# Altium schematic sheet 24VDC_IN.SchDoc
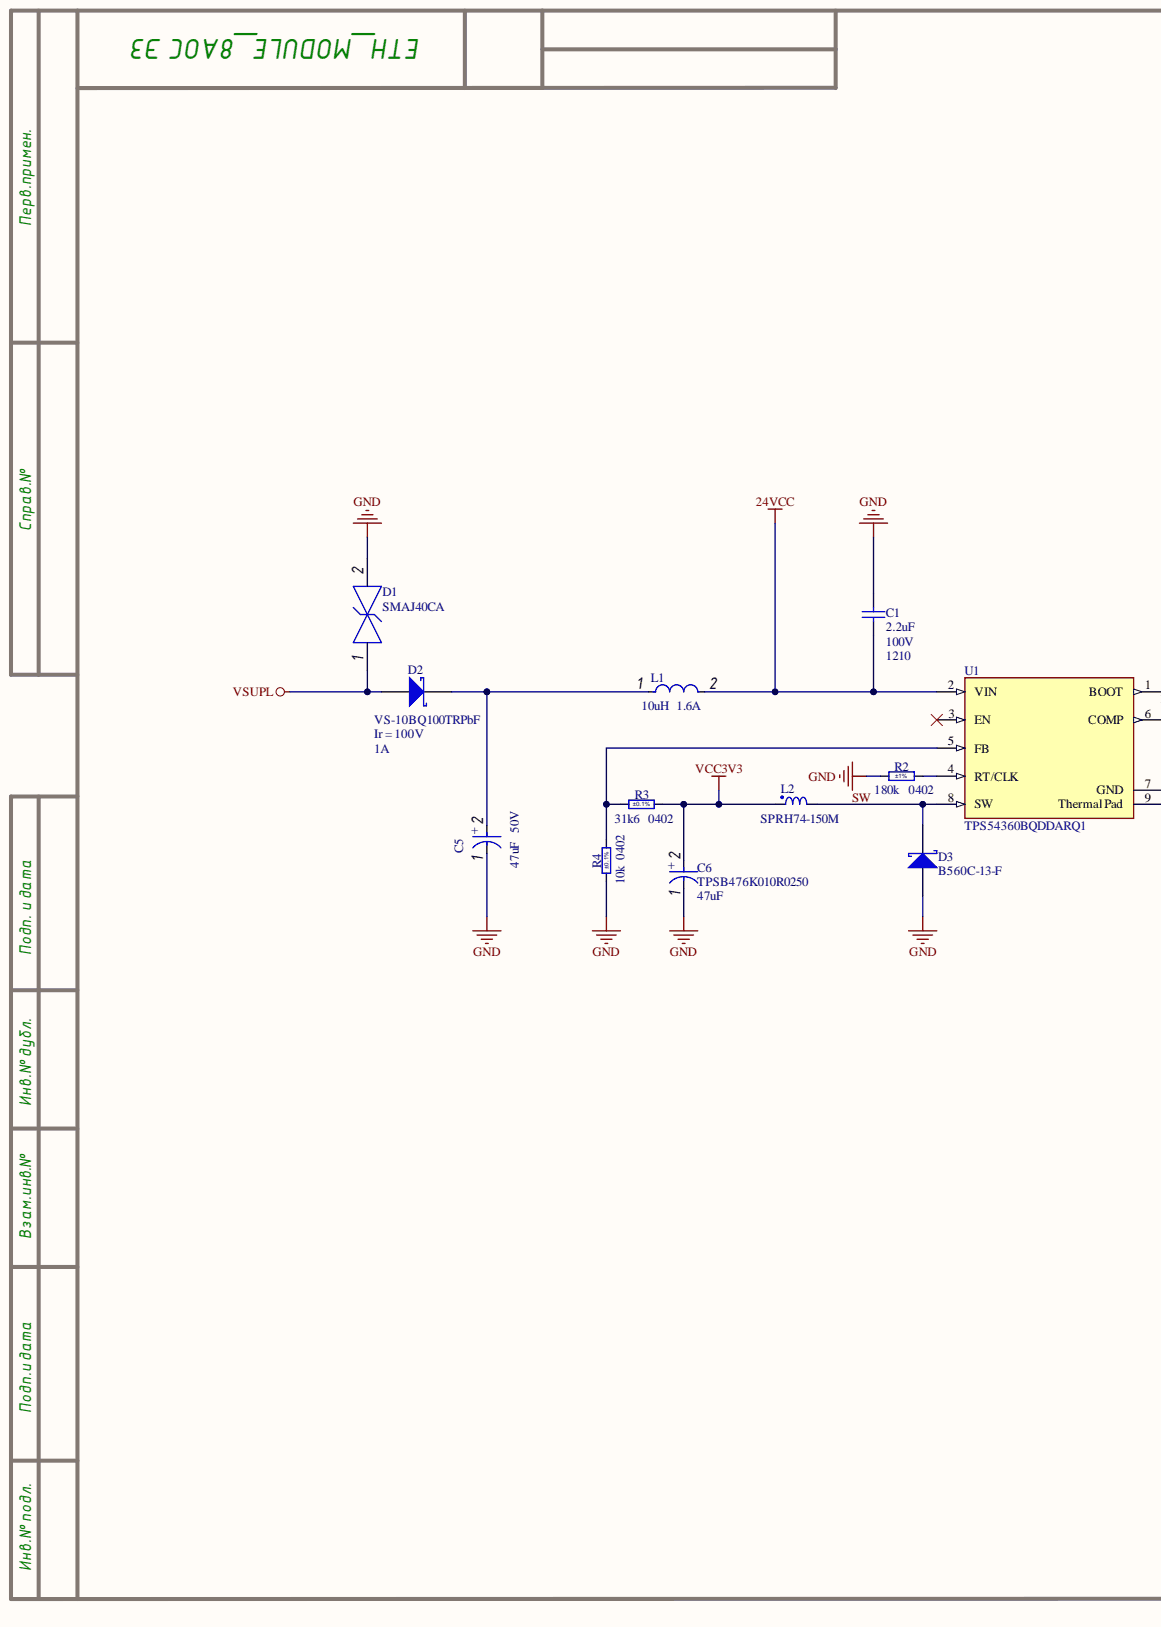
[diagram p0: 24VDC_IN.SchDoc, left]
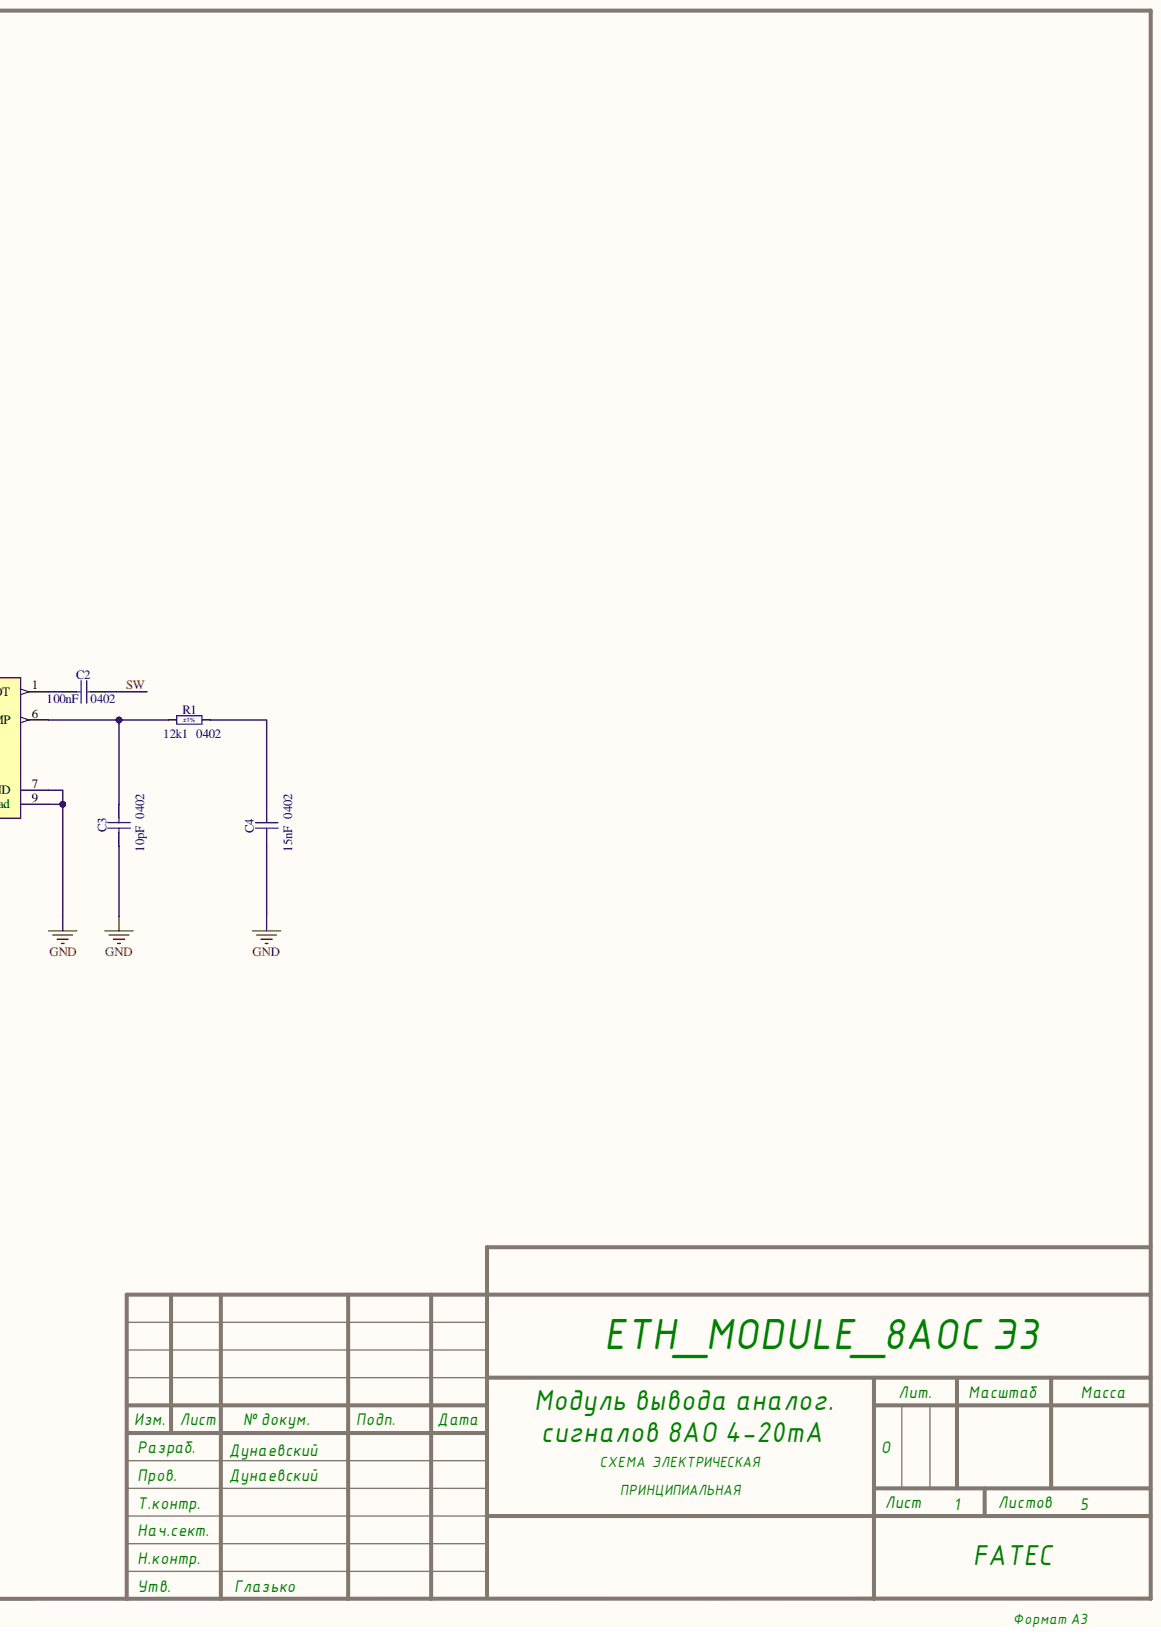
[diagram p1: 24VDC_IN.SchDoc, right]

## Parts
C1  2.2uF  Case: 1210  Voltage: 100V  [CAPC3225X230N]
C2  100nF  Case: 0402  [CAPC1005X55N]
C3  10pF  Case: 0402  [CAPC1005X55N]
C4  15nF  Case: 0402  [CAPC1005X55N]
C5  Capacitance: 47uF  Voltage: 50V  [FP-FKE-MFG]  (hidden comment: EEEFK1H470P)
C6  TPSB476K010R0250  Capacitance: 47uF  [FP-TPSB-MFG]
D1  SMAJ40CA  [FP-SMAJ-MFG]
D2  VS-10BQ100TRPbF  Voltage - DC Reverse (Vr) (Max): Ir = 100V  [VISH-SMB-2_V]
D3  B560C-13-F  [DIOD-B5X0C-2_M]
L1  Current Rating: 1.6A  Inductance: 10uH  [FP-LQH5BPB_T0-MFG]  (hidden comment: LQH5BPN100MT0L)
L2  SPRH74-150M  [B82472G6103M000]
R1  12k1  Case: 0402  Tolerance: ±1%  [RESC1005X40N]
R2  180k  Case: 0402  Tolerance: ±1%  [RESC1005X40N]
R3  31k6  Case: 0402  Tolerance: ±0.1%  [RESC1005X35N]
R4  10k  Case: 0402  Tolerance: ±0.1%  [RESC1005X35N]
U1  TPS54360BQDDARQ1  [FP-DDA0008E-MFG]

## Nets
24VCC: C1.1 L1.2 U1.2(VIN)
GND: C1.2 C3.1 C4.1 C5.1 C6.1 D1.2 D3.2 R2.1 R4.1 U1.7(GND) U1.9(Thermal_Pad)
VCC3V3: C6.2 L2.1 R3.2
VSUPL: D1.1 D2.1
SW: C2.2 D3.1 L2.2 U1.8(SW)
NetC2_1: C2.1 U1.1(BOOT)
NetC3_2: C3.2 R1.1 U1.6(COMP)
NetC4_2: C4.2 R1.2
NetC5_2: C5.2 D2.2 L1.1
NetR2_2: R2.2 U1.4(RT/CLK)
NetR3_1: R3.1 R4.2 U1.5(FB)

Not connected: U1 3(EN)

## Library data of the parts
C1 = CC1210_2.2UF_100V_10%_X7R_MURATA_GCJ32DR72A225KA01L: Non-polarised capacitor; Manufacturer: MURATA; Part Description: Soft Termination SMD Chip Monolithic Ceramic Capacitor for Automotive; Database Table Name: Capacitors SMD; TC: X7R; Tolerance: ±10%; Component Kind: Standard; Component Type: Standard; ComponentHeight: 2.3mm; CreateDate: 10.05.2017; HelpURL: \\cern.ch\dfs\Applications\Altium\Datasheets\CC1210_MURATA_GCJ32DR72A225KA01L.pdf; LatestRevisionDate: 10.05.2017; Library Name: Capacitors.DbLib; Manufacturer Part Number: GCJ32DR72A225KA01L; Mounted: Yes; Part Number: CC1210_2.2UF_100V_10%_X7R_MURATA_GCJ32DR72A225KA01L; Pin Count: 2; Sense: No; SMD: Yes; Socket: No; Status: Not Recommended; Value: 2.2uF; Author: CERN DEM JLC
C2 = CC0402_100NF_16V_10%_X7R: Non-polarised capacitor; Manufacturer: GENERIC; Manufacturer1 Part Number: GRM155R71C104KA88D; Part Description: SMD Multilayer Chip Ceramic Capacitor; Database Table Name: Capacitors SMD; TC: X7R; Tolerance: ±10%; Voltage: 16V; Component Kind: Standard; Component Type: Standard; CreateDate: 05.12.2013; HelpURL: \\cern.ch\dfs\Applications\Altium\Datasheets\CC0402_X7R_MURATA_GRM.pdf; LatestRevisionDate: 03.12.2007; Library Name: Capacitors.DbLib; Manufacturer1 ComponentHeight: 0.55mm; Manufacturer1 Example: MURATA; Manufacturer Part Number: CC0402_100NF_16V_10%_X7R; Mounted: Yes; Part Number: CC0402_100NF_16V_10%_X7R; Pin Count: 2; Sense: No; SMD: Yes; Socket: No; Status: Not Recommended; Value: 100nF; Author: CERN DEM JLC
C3 = CC0402_10PF_50V_5%_C0G: Non-polarised capacitor; Manufacturer: GENERIC; Manufacturer1 Part Number: C0402C100J5GACTU; Part Description: SMD Multilayer Chip Ceramic Capacitor; Database Table Name: Capacitors SMD; TC: C0G/NP0; Tolerance: ±5%; Voltage: 50V; Component Kind: Standard; Component Type: Standard; CreateDate: 03.12.2007; HelpURL: \\cern.ch\dfs\Applications\Altium\Datasheets\CC0402_C0G_KEMET_(Commercial Grade).pdf; LatestRevisionDate: 22.04.2015; Library Name: Capacitors.DbLib; Manufacturer1 ComponentHeight: 0.55mm; Manufacturer1 Example: KEMET; Manufacturer Part Number: CC0402_10PF_50V_5%_C0G; Mounted: Yes; Part Number: CC0402_10PF_50V_5%_C0G; Pin Count: 2; Sense: No; SMD: Yes; Socket: No; Status: Preferred; Value: 10pF; Author: CERN DEM JLC
C4 = CC0402_15NF_50V_5%_X7R: Non-polarised capacitor; Manufacturer: GENERIC; Manufacturer1 Part Number: C0402C153J5RACTU; Part Description: SMD Multilayer Chip Ceramic Capacitor; Database Table Name: Capacitors SMD; TC: X7R; Tolerance: ±5%; Voltage: 50V; Component Kind: Standard; Component Type: Standard; CreateDate: 02.09.2019; HelpURL: \\cern.ch\dfs\Applications\Altium\Datasheets\CC0402_X7R_KEMET.pdf; LatestRevisionDate: 02.09.2019; Library Name: Capacitors.DbLib; Manufacturer1 ComponentHeight: 0.55mm; Manufacturer1 Example: KEMET; Manufacturer Part Number: CC0402_15NF_50V_5%_X7R; Mounted: Yes; Part Number: CC0402_15NF_50V_5%_X7R; Pin Count: 2; Sense: No; SMD: Yes; Socket: No; Status: Preferred; Value: 15nF; Author: CERN DEM BC
C5 = CMP-05427-000074-1: CAP ALUM 47UF 20% 50V SMD; Dielectric Material: Aluminium; ESR (Equivalent Series Resistance): 680mR; Leakage Current: 3uA; Life (Hours): 2000Hour; Ripple Current: 195mA; Case/Package: Radial; Component Kind: Standard; Construction: Cylindrical; Diameter: 8mm; Height: 6.2mm; Height - Seated (Max): 0.244inch; Impedance: 680mR; Lead Free: Lead Free; Length: 6.2mm; Library Name: Altium Content Vault; Material: Aluminium; Max Operating Temperature: 105°C; Min Operating Temperature: -55°C; Mount: Surface Mount; Number of Pins: 2; Package Quantity: 1000; Packaging: Tape and Reel; Radiation Hardening: No; REACH SVHC: No SVHC; Ripple Current (AC): 195mA; RoHS Compliant: Yes; Series: FK; Size: 6.2mm; Termination: SMD/SMT; Tolerance: 20%; Voltage Rating: 50V; Voltage Rating (DC): 50V; Width: 8.3mm
C6 = CMP-04424-000465-1: CAP TANT 47UF 10% 10V 1411; Dielectric Material: Tantalum; Dissipation Factor: 8%; ESR (Equivalent Series Resistance): 250mR; Leakage Current: 4.7uA; Polarity: Polar; Case Code (Imperial): 1210; Case Code (Metric): 3528; Case/Package: 1210; Component Kind: Standard; Construction: Flat; Depth: 2.8mm; Features: General Purpose; Height: 1.9mm; Height - Seated (Max): 0.083inch; Lead Free: Lead Free; Lead Pitch: 1.4mm; Length: 3.5mm; Library Name: Altium Content Vault; Max Operating Temperature: 125°C; Min Operating Temperature: -55°C; Mount: Surface Mount; Package Quantity: 1; Packaging: Tape and Reel; Radiation Hardening: No; REACH SVHC: No SVHC; RoHS Compliant: Yes; Series: TPS; Termination: SMD/SMT; Tolerance: 10%; Voltage: 10V; Voltage Rating: 10V; Voltage Rating (DC): 10V; Width: 0.11inch
D1 = CMP-08607-000026-1: TVS DIODE 40V 64.5V DO214AC; Manufacturer: Littelfuse Inc; Breakdown Voltage: 44.4V; Direction: Bidirectional; Max Junction Temp: +150°C; Number Of Channels: 1; Peak Pulse Current: 6.2A; Peak Pulse Power: 400W; Automotive: No; Category: Diode; Clamping Voltage: 64.5V; Component Kind: Standard; ComponentLink2Description: Datasheet; ComponentLink2URL: https://www.littelfuse.com/~/media/electronics/datasheets/tvs_diodes/littelfuse_tvs_diode_smaj_datasheet.pdf.pdf; Device Class L1: Circuit Protection; Device Class L2: TVS Diodes; Device Class L3: unset; Digikey Description: TVS DIODE 40V 64.5V DO214AC; Height: 2.29mm; Lead Free: Yes; Library Name: Altium Content Vault; Manufacturer Part Number: SMAJ40CA; Mouser Description: Transient Voltage Suppressor, TVS, SMAJ Series, Bidirectional, 40 V, DO-214AC, 2, 44.4 V; Octopart: https://octopart.com/smaj40ca-littelfuse-350118; Package: SMAJ; Reverse Standoff Voltage: 40V; RoHS: TRUE; Temperature: -65°C to +150°C; Temperature Range High: +150°C; Temperature Range Low: -65°C
D2 = CMP-2000-07403-1: Schottky Rectifier, 1.0 A, 100 V, -55 to 175 degC, 2-Pin SMD, RoHS, Tape and Reel; Manufacturer: Hottech; Catalog Drawings: SMBSeriesTop    SMBSeriesSide; Current - Average Rectified (Io): 1A; Current - Reverse Leakage @ Vr: 500µA@100V; Dynamic Catalog: SchottkyDiode; Other Names: 10BQ100PBFDKR  VS-10BQ100PBFDKR  VS-10BQ100PBFDKR-ND  VS10BQ100PBFDKR-ND; Speed: FastRecovery=  200mA(Io); Thermal Resistance: 36°C/WJl; Voltage - Forward (Vf) (Max) @ If: 780mV@1A; Category: DiscreteSemiconductorProducts; Component Kind: Standard; Datasheet URL: https://datasheet.ciiva.com/15045/10bq100p-15045632.pdf; Datasheet Version: 03/2010; Diode Type: Schottky; Family: Diodes,Rectifiers-Single; Junction Operating Temp: -55°C~175°C; Library Name: Altium Content Vault; Manufacturer URL: http://www.vishay.com/; Minimum Quantity: Schottky; Mounting Technology: SurfaceMount; Mounting Type: SurfaceMount; Operating Temperature - Junction: -55°C~175°C; Package / Case: DO-214AA,SMB; Package Description: 2-Pin Surface Mount Device, Body 4.40 x 3.55 mm; Package Reference: SMB; Packaging: Digi-Reel®; Quantity Available: Digi-Reel®AlternatePackaging; Standard Package: 1; Supplier Device Package: DO-214AA(SMB)
D3 = CMP-2000-05098-2: Schottky Barrier Rectifier, 5 A, 60 V, -55 to 125 degC, 2-Pin SMD, RoHS, Tape and Reel; Brand: DiodesIncorporated; Configuration: Single; If - Forward Current: 5A; Ifsm - Forward Surge Current: 100A; Ir - Reverse Current: 500uA; Unit Weight: 0.007408oz; Vf - Forward Voltage: 0.7V; Vrrm - Repetitive Reverse Voltage: 60V; Component Kind: Standard; Datasheet URL: https://datasheet.ciiva.com/27052/1538961-27052355.pdf; Datasheet Version: Rev. 7-2, 06/2007; Factory Pack Quantity: 3000; Height: 2.5mm; Length: 7.11mm; Library Name: Altium Content Vault; Manufacturer: DiodesIncorporated; Manufacturer URL: http://www.diodes.com/; Maximum Operating Temperature: +125C; Minimum Operating Temperature: -55C; Mounting Style: SMD/SMT; Operating Temperature Range: -55Cto+125C; Package / Case: SMC; Packaging: Reel; Product: SchottkyDiodes; Product Category: SchottkyDiodes&Rectifiers; RoHS: True; Series: B560; Technology: Si; Termination Style: SMD/SMT; Type: SchottkyBarrierRectifier; Width: 6.22mm
L1 = CMP-06042-011567-1: Wire Wound Ferrite Inductor for Power Lines 10μH ±20% 0.1272Ω 1.60A 2020; DC Resistance (DCR): 106mR; Max DC Current: 2A; Self Resonant Frequency: 25MHz; Shielding: Shielded; Test Frequency: 100kHz; Case Code (Imperial): 2220; Case Code (Metric): 5650; Case/Package: 2020; Component Kind: Standard; Core Material: Ferrite; DC Current: 1.6A; Depth: 5.2mm; Height: 2mm; Height - Seated (Max): 0.086inch; Inductance Tolerance: 20%; Lead Free: Lead Free; Length: 5mm; Library Name: Altium Content Vault; Max Operating Temperature: 125°C; Military Standard: Not; Min Operating Temperature: -40°C; Mount: Surface Mount; Package Quantity: 1; Packaging: Tape and Reel; REACH SVHC: No SVHC; Resistor Type: Wirewound; Termination: SMD/SMT; Tolerance: 20%; Width: 5mm
L2 = SPRH74-150M: Inductor SMD; Core Material: Ferrite; Current Rating: 2.1A; DC Resistance (DCR): 61.5mΩ; Inductance: 10µH; Inductance Tolerance: 20%; Max DC Current: 3.6A; Saturation Current: 2.5A; Self Resonant Frequency: 23MHz; Shielding: Shielded; Test Frequency: 1kHz; Ambient Temperature Range High: 85°C; Ambient Temperature Range Low: -50°C; Case/Package: Nonstandard; Component Kind: Standard; Composition: Wirewound; Depth: 7.3mm; Height: 4.3mm; Height - Seated (Max): 4.2; Lead Free: Lead Free; Lead Pitch: 4mm; Length: 7.3mm; Library Name: Schlib.SchLib; Max Operating Temperature: 125°C; Min Operating Temperature: -50°C; Mount: Surface Mount; Number of Pins: 2; Packaging: Cut Tape (CT); RoHS: Compliant; Termination: SMD/SMT; Tolerance: 20%; Width: 7.3mm
R1 = R0402_12K1_1%_0.0625W_100PPM: Resistor - 1%; Manufacturer: GENERIC; Manufacturer1 Part Number: 232270671213L or RC0402FR-0712K1L; Part Description: General Purpose Thick Film Chip Resistor; Database Table Name: Resistors SMD; PressFit: No; TC: ±100ppm/°C; Component Kind: Standard; Component Type: Standard; CreateDate: 24.10.2012; HelpURL: \\cern.ch\dfs\Applications\Altium\Datasheets\R0402_Phycomp_RC0402.pdf; LatestRevisionDate: 24.10.2012; Library Name: Resistors.DbLib; Manufacturer1 ComponentHeight: 0.4mm; Manufacturer1 Example: YAGEO PHYCOMP; Manufacturer Part Number: R0402_12K1_1%_0.0625W_100PPM; Mounted: Yes; Part Number: R0402_12K1_1%_0.0625W_100PPM; Pin Count: 2; Power: 0.0625W; Sense: No; SMD: Yes; Socket: No; Status: Not Recommended; Value: 12k1; Author: CERN DEM JLC
R2 = R0402_180K_1%_0.0625W_100PPM: Resistor - 1%; Manufacturer: GENERIC; Manufacturer1 Part Number: 232270671804L; Part Description: General Purpose Thick Film Chip Resistor; Database Table Name: Resistors SMD; PressFit: No; TC: ±100ppm/°C; Component Kind: Standard; Component Type: Standard; CreateDate: 03.12.2007; HelpURL: \\cern.ch\dfs\Applications\Altium\Datasheets\R0402_Phycomp_RC0402.pdf; LatestRevisionDate: 17.10.2012; Library Name: Resistors.DbLib; Manufacturer1 ComponentHeight: 0.4mm; Manufacturer1 Example: YAGEO PHYCOMP; Manufacturer Part Number: R0402_180K_1%_0.0625W_100PPM; Mounted: Yes; Part Number: R0402_180K_1%_0.0625W_100PPM; Pin Count: 2; Power: 0.0625W; Sense: No; SMD: Yes; Socket: No; Status: Not Recommended; Value: 180k; Author: CERN DEM JLC
R3 = R0402_31K6_0.1%_0.063W_25PPM_YAGEO_RT: Resistor - 0.1%; Manufacturer: YAGEO; Manufacturer Part Number: RT0402BRD0731K6L; Part Description: Thin Film Chip Resistor; Database Table Name: Resistors SMD; Power: 0.063W; PressFit: No; TC: ±25ppm/°C; Voltage: 50V; Component Kind: Standard; Component Type: Standard; ComponentHeight: 0.35mm; CreateDate: 30.07.2020; HelpURL: \\cern.ch\dfs\Applications\Altium\Datasheets\R0402_YAGEO_RT.pdf; LatestRevisionDate: 30.07.2020; Library Name: Resistors.DbLib; Mounted: Yes; Part Number: R0402_31K6_0.1%_0.063W_25PPM_YAGEO_RT; Pin Count: 2; Sense: No; SMD: Yes; Socket: No; Status: Not Recommended; Value: 31k6; Author: CERN DEM BC
R4 = R0402_10K_0.1%_0.063W_25PPM: Resistor - 0.1%; Manufacturer: GENERIC; Manufacturer1 Part Number: CPF0402B10KE1; Part Description: Thin Film Precision Resistor; Database Table Name: Resistors SMD; Power: 0.063W; PressFit: No; TC: ±25ppm/°C; Component Kind: Standard; Component Type: Standard; CreateDate: 22.09.2015; HelpURL: \\cern.ch\dfs\Applications\Altium\Datasheets\R0402_TYCO_CPF.pdf; LatestRevisionDate: 22.09.2015; Library Name: Resistors.DbLib; Manufacturer1 ComponentHeight: 0.35mm; Manufacturer1 Example: TE CONNECTIVITY; Manufacturer Part Number: R0402_10K_0.1%_0.063W_25PPM; Mounted: Yes; Part Number: R0402_10K_0.1%_0.063W_25PPM; Pin Count: 2; Sense: No; SMD: Yes; Socket: No; Status: Not Recommended; Value: 10k; Author: CERN DEM JMW
U1 = CMP-04918-000007-1: IC REG BCK SPLIT RAIL ADJ 8SOPWR; Manufacturer: Texas Instruments; Automotive Grade: Grade 1; Max Duty Cycle: 98%; Max Junction Temp: +150°C; Max Supply Voltage: 60V; Min Supply Voltage: 4.5V; Nominal Supply Current: 146uA; Number Of Outputs: 1; Output Current: 3.5A; Output Type: AdjustableProgrammable; Output Voltage: 0.8-58.8V; Standoff Height: 0mm; Switching Frequency: 100-2500kHz; Switching Topology: Buck; Ambient Temperature Range High: +125°C; Ambient Temperature Range Low: -40°C; Automotive: Yes; Category: IC; Component Kind: Standard; ComponentLink2Description: Datasheet; ComponentLink2URL: http://www.ti.com/lit/ds/symlink/tps54360b-q1.pdf; Device Class L1: Integrated Circuits (ICs); Device Class L2: Power Management ICs; Device Class L3: Voltage Regulators - Switching; Digikey Description: IC REG BCK SPLIT RAIL ADJ 8SOPWR; Height: 1.7mm; Lead Free: Yes; Library Name: Altium Content Vault; Manufacturer Part Number: TPS54360BQDDARQ1; Octopart: https://octopart.com/tps54360bqddarq1-texas+instruments-80336296; Package: DDA0008E; RoHS: TRUE; Temperature: -40°C to +125°C; Temperature Range High: +125°C; Temperature Range Low: -40°C
